# Altium schematic sheet PowerSupply.SchDoc
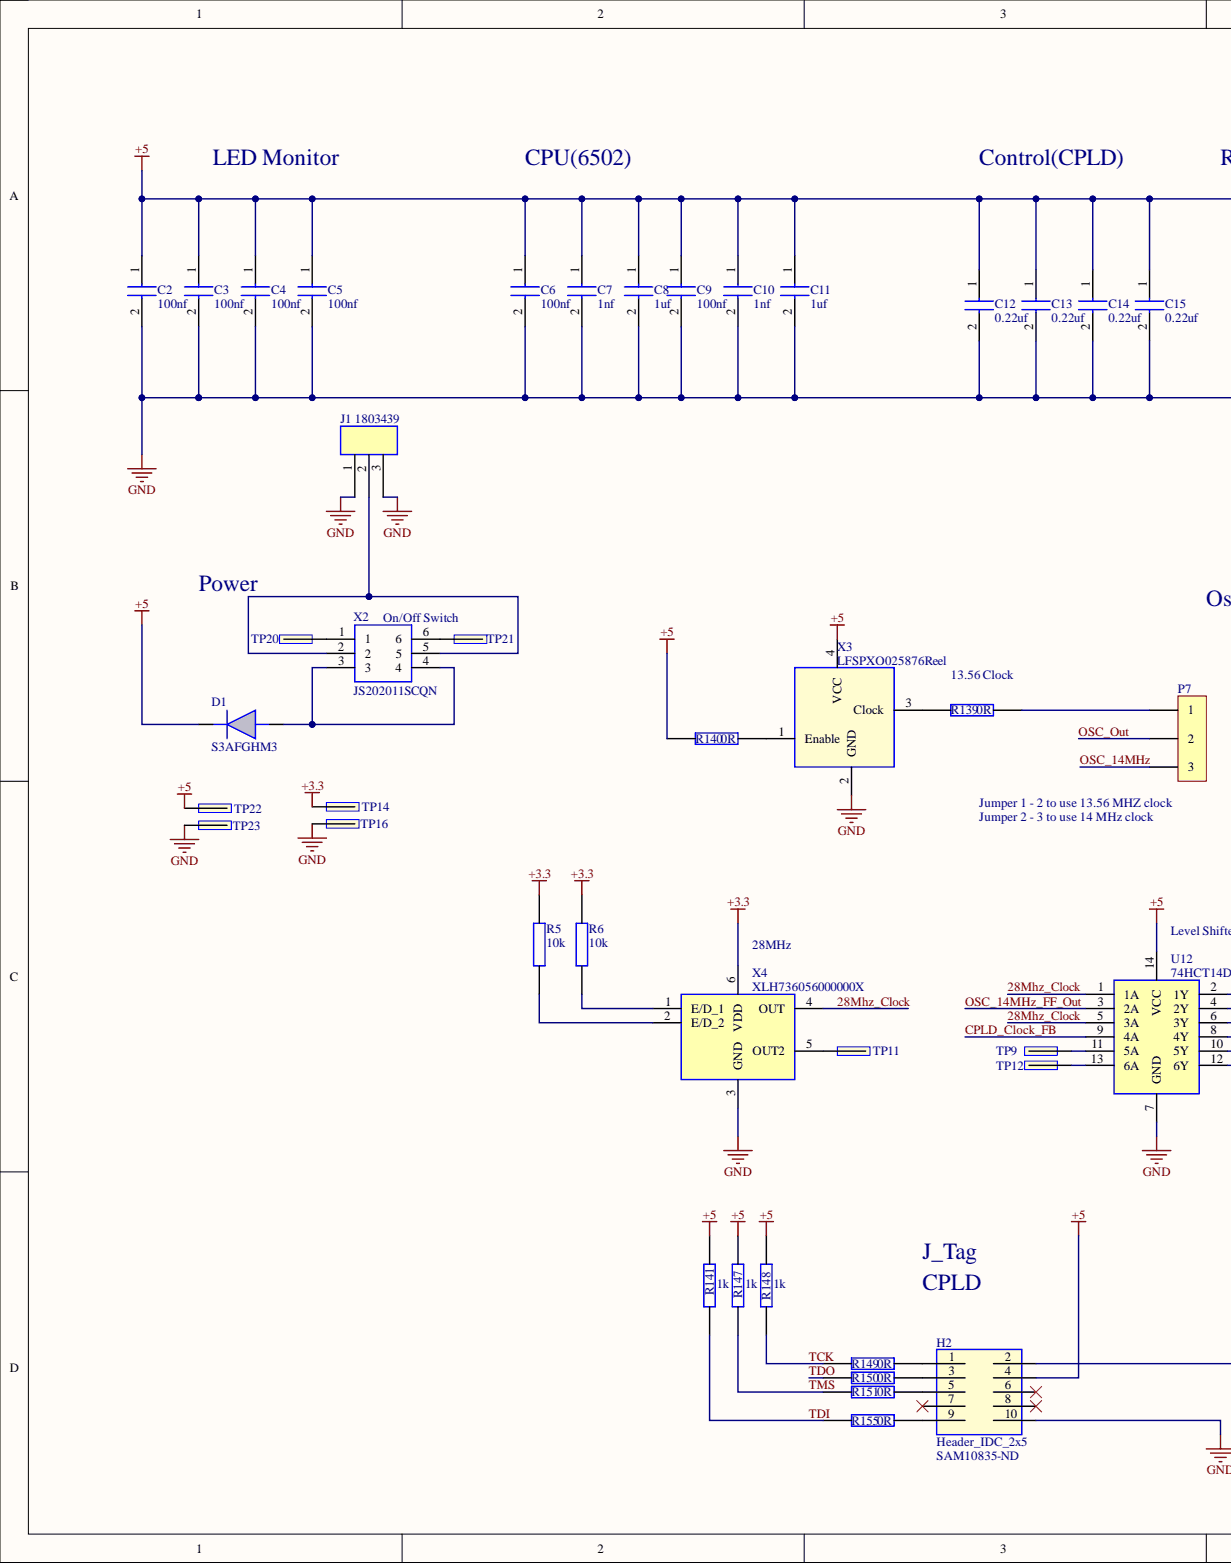
[diagram p0: PowerSupply.SchDoc, left]
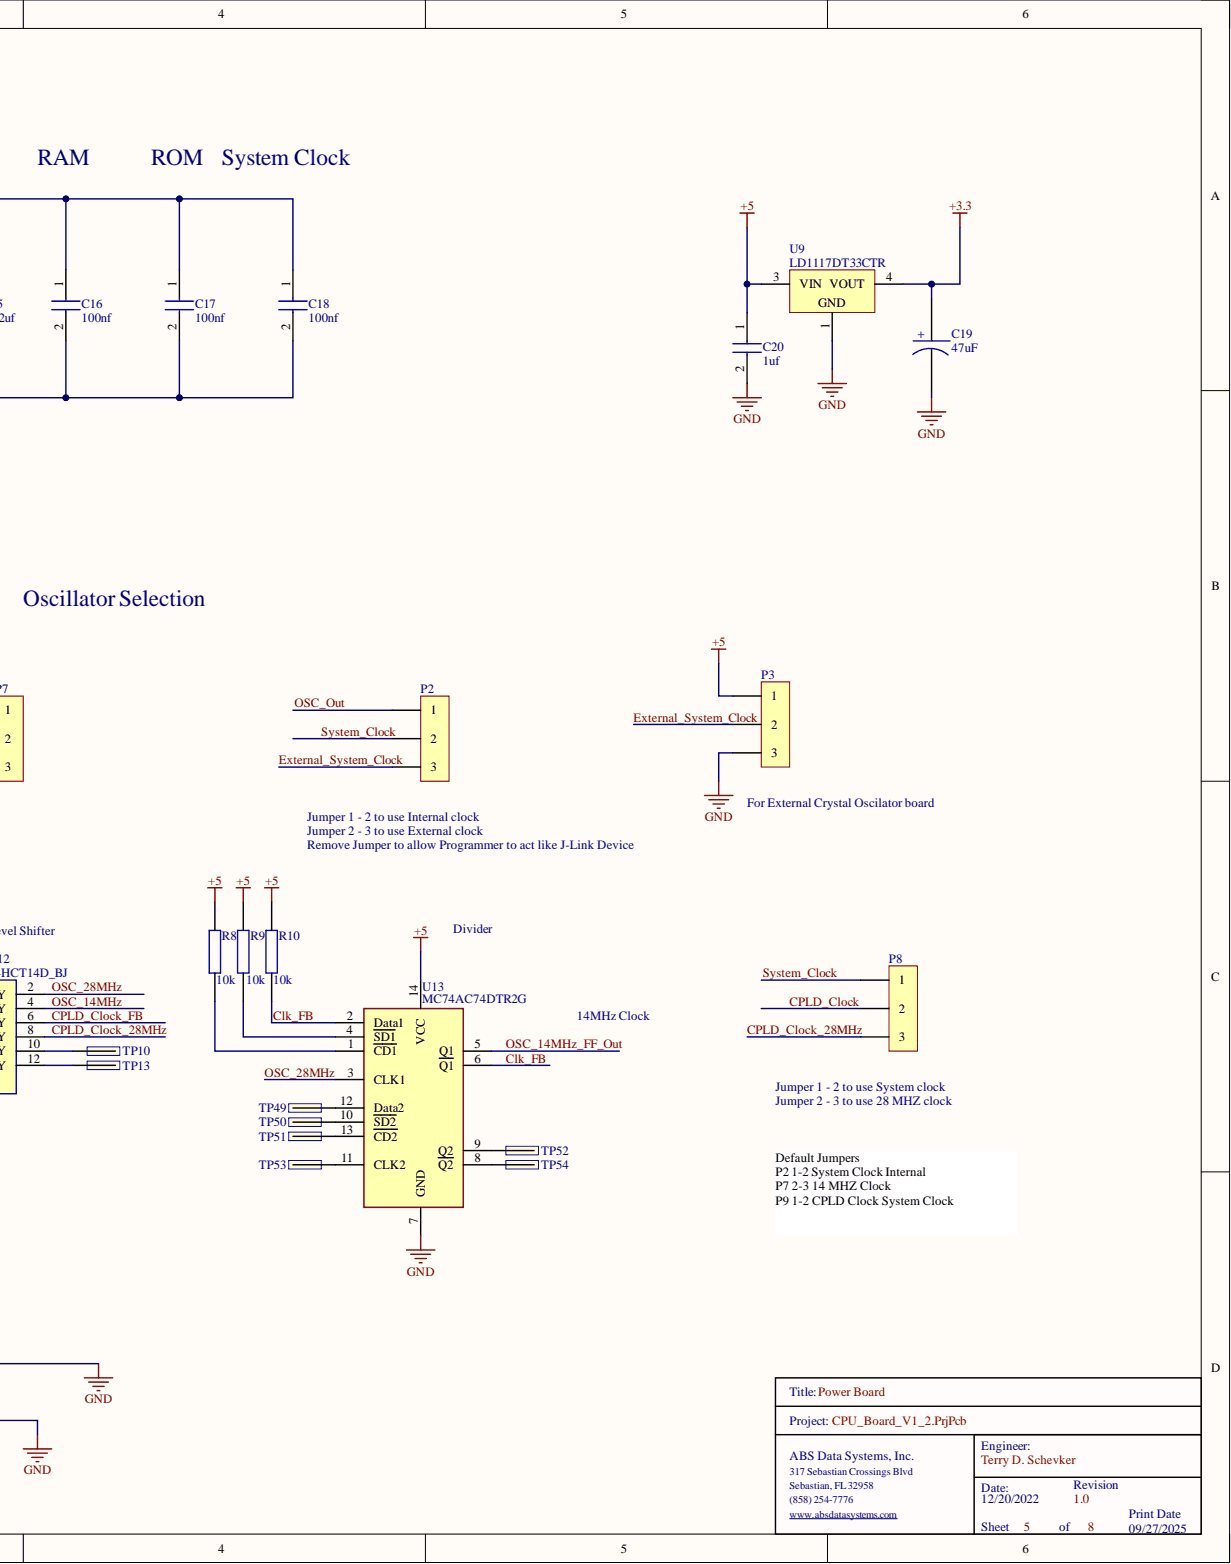
[diagram p1: PowerSupply.SchDoc, right]

## Parts
C2  100nf  [0603]
C3  100nf  [0603]
C4  100nf  [0603]
C5  100nf  [0603]
C6  100nf  [0603]
C7  1nf  [0603]
C8  1uf  [0603]
C9  100nf  [0603]
C10  1nf  [0603]
C11  1uf  [0603]
C12  0.22uf  [0603]
C13  0.22uf  [0603]
C14  0.22uf  [0603]
C15  0.22uf  [0603]
C16  100nf  [0603]
C17  100nf  [0603]
C18  100nf  [0603]
C19  47uF  [CapSMD_6.3x5.8]
C20  1uf  [0603]
D1  S3AFGHM3  [do-221ac]
H2  Header_IDC_2x5  Supplier Part Number 1: SAM10835-ND  [HDR2X5]
J1  1803439  [1803439]
P2  MHDR1X3_100  [MHDR1X3_100]  (value not drawn)
P3  MHDR1X3_100  [MHDR1X3_100]  (value not drawn)
P7  MHDR1X3_100  [MHDR1X3_100]  (value not drawn)
P8  MHDR1X3_100  [MHDR1X3_100]  (value not drawn)
R5  10k  [0603]
R6  10k  [0603]
R8  10k  [0603]
R9  10k  [0603]
R10  10k  [0603]
R139  0R  [0603]  (value not drawn)
R140  0R  [0603]  (value not drawn)
R141  1k  [0603]
R147  1k  [0603]
R148  1k  [0603]
R149  0R  [0603]  (value not drawn)
R150  0R  [0603]  (value not drawn)
R151  0R  [0603]  (value not drawn)
R155  0R  [0603]  (value not drawn)
TP9  (no value)  [TP]
TP10  TP  [TP]
TP11  TP  [TP]
TP12  (no value)  [TP]
TP13  TP  [TP]
TP14  TP  [TP]
TP16  TP  [TP]
TP20  (no value)  [TP]
TP21  (no value)  [TP]
TP22  TP  [TP]
TP23  TP  [TP]
TP49  TP  [TP]
TP50  TP  [TP]
TP51  TP  [TP]
TP52  TP  [TP]
TP53  TP  [TP]
TP54  TP  [TP]
U9  LD1117DT33CTR  [TO-252_N]
U12  74HCT14D_BJ  [SOIC127P600X175-14N]
U13  MC74AC74DTR2G  [SOP65P640X120-14N]
X2  JS202011SCQN  [JS202011SCQN]
X3  LFSPXO025876Reel  [LFSPXO025876Reel]
X4  XLH736056000000X  [XLH736056000000X]

## Nets
+3.3: C19.1 R5.2 R6.2 TP14.1 U9.4 X4.6(VDD)
+5: C2.1 C3.1 C4.1 C5.1 C6.1 C7.1 C8.1 C9.1 C10.1 C11.1 C12.1 C13.1 C14.1 C15.1 C16.1 C17.1 C18.1 C20.1 D1.1 H2.4 P3.1 R8.2 R9.2 R10.2 R140.1 R141.1 R147.1 R148.1 TP22.1 U9.3 U12.14(VCC) U13.14(VCC) X3.4(VCC)
GND: C2.2 C3.2 C4.2 C5.2 C6.2 C7.2 C8.2 C9.2 C10.2 C11.2 C12.2 C13.2 C14.2 C15.2 C16.2 C17.2 C18.2 C19.2 C20.2 H2.2 H2.10 J1.1 J1.3 P3.3 TP16.1 TP23.1 U9.1 U12.7(GND) U13.7(GND) X3.2(GND) X4.3(GND)
28Mhz_Clock: U12.1(1A) U12.5(3A) X4.4(OUT)
Clk_FB: R10.1 U13.2(Data1) U13.6(Q1)
CPLD_Clock: P8.2
CPLD_Clock_28MHz: P8.3 U12.8(4Y)
CPLD_Clock_FB: U12.6(3Y) U12.9(4A)
External_System_Clock: P2.3 P3.2
OSC_14MHz: P7.3 U12.4(2Y)
OSC_14MHz_FF_Out: U12.3(2A) U13.5(Q1)
OSC_28MHz: U12.2(1Y) U13.3(CLK1)
OSC_Out: P2.1 P7.2
System_Clock: P2.2 P8.1
TCK: R148.2 R149.1
TDI: R141.2 R155.1
TDO: R150.1
TMS: R147.2 R151.1
NetD1_2: D1.2 X2.3 X2.4
NetH2_1: H2.1 R149.2
NetH2_3: H2.3 R150.2
NetH2_5: H2.5 R151.2
NetH2_9: H2.9 R155.2
NetJ1_2: J1.2 X2.2 X2.5
NetP7_1: P7.1 R139.2
NetR5_1: R5.1 X4.2(E/D_2)
NetR6_1: R6.1 X4.1(E/D_1)
NetR8_1: R8.1 U13.1(CD1)
NetR9_1: R9.1 U13.4(SD1)
NetR139_1: R139.1 X3.3(Clock)
NetR140_2: R140.2 X3.1(Enable)
NetTP9_1: TP9.1 U12.11(5A)
NetTP10_1: TP10.1 U12.10(5Y)
NetTP11_1: TP11.1 X4.5(OUT2)
NetTP12_1: TP12.1 U12.13(6A)
NetTP13_1: TP13.1 U12.12(6Y)
NetTP20_1: TP20.1 X2.1
NetTP21_1: TP21.1 X2.6
NetTP49_1: TP49.1 U13.12(Data2)
NetTP50_1: TP50.1 U13.10(SD2)
NetTP51_1: TP51.1 U13.13(CD2)
NetTP52_1: TP52.1 U13.9(Q2)
NetTP53_1: TP53.1 U13.11(CLK2)
NetTP54_1: TP54.1 U13.8(Q2)

Not connected: H2 6 7 8

## Library data of the parts
C2-C6 C9 C12-C18 = CL10B104JB8NNNC: Samsung Electro-Mechanics 0603 100nf; Component Kind: Standard; Library Name: Capacitors.SchLib
C7 C10 = CL10B102KB8NNNL: Samsung Electro-Mechanics 0603 1nf; Component Kind: Standard; Library Name: Capacitors.SchLib
C8 C11 C20 = CL10B105KA8NNNL: Samsung Electro-Mechanics 0603 1uf; Component Kind: Standard; Library Name: Capacitors.SchLib
C19 = UUD1C470MCL1GS: CAP ALUM 47UF 20% 16V SMD; Manufacturer: Nichicon; Dielectric Material: Aluminium; Dissipation Factor: 0.2%; Impedance: 440mR; Lead Diameter: 0.8mm; Leakage Current: 3uA; Life (Hours): 2000Hour; Ripple Current: 230mA; Capacitance: 47uF; Case/Package: Radial; Category: Aluminum Electrolytic Capacitors; Component Kind: Standard; ComponentLink1Description: https://datasheet.ciiva.com/30522/getdatasheetpartid-449848-30522051.pdf?src-supplier=Verical; ComponentLink1URL: https://datasheet.ciiva.com/30522/getdatasheetpartid-449848-30522051.pdf?src-supplier=Verical; ComponentLink2Description: https://datasheet.ciiva.com/96/e-ud-96997.pdf?src-supplier=Digi-Key; ComponentLink2URL: https://datasheet.ciiva.com/96/e-ud-96997.pdf?src-supplier=Digi-Key; ComponentLink3Description: https://datasheet.ciiva.com/11776/0900766b80fa39e7-11776889.pdf?src-supplier=RSComponents; ComponentLink3URL: https://datasheet.ciiva.com/11776/0900766b80fa39e7-11776889.pdf?src-supplier=RSComponents; ComponentLink4Description: https://datasheet.ciiva.com/96/e-ud-96998.pdf?src-supplier=Digi-Key; ComponentLink4URL: https://datasheet.ciiva.com/96/e-ud-96998.pdf?src-supplier=Digi-Key; ComponentLink5Description: https://datasheet.ciiva.com/96/e-ud-96999.pdf?src-supplier=Digi-Key; ComponentLink5URL: https://datasheet.ciiva.com/96/e-ud-96999.pdf?src-supplier=Digi-Key; ComponentLink6Description: https://datasheet.ciiva.com/11686/e-ud-11686236.pdf?src-supplier=Avnet; ComponentLink6URL: https://datasheet.ciiva.com/11686/e-ud-11686236.pdf?src-supplier=Avnet; ComponentLink7Description: https://datasheet.ciiva.com/16502/e-ud-16502750.pdf?src-supplier=Future+Electronics; ComponentLink7URL: https://datasheet.ciiva.com/16502/e-ud-16502750.pdf?src-supplier=Future+Electronics; ComponentLink8Description: https://datasheet.ciiva.com/7781/e-ud-7781695.pdf?src-supplier=Element14; ComponentLink8URL: https://datasheet.ciiva.com/7781/e-ud-7781695.pdf?src-supplier=Element14; Contact Plating: Tin; Diameter: 6.3mm; Height: 5.8mm; Height - Seated (Max): 0.228inch; Lead Free: Lead Free; Lead Pitch: 2.2mm; Length: 5.8mm; Library Name: Capacitors.SchLib; Manufacturer Part Number: UUD1C470MCL1GS; Max Operating Temperature: 105°C; Min Operating Temperature: -55°C; Number of Pins: 2; Package Quantity: 1000; Packaging: Tape and Reel; Pricing 1: 1=0.50000, 10=0.34600, 50=0.24220, 100=0.20760, 500=0.15568 (USD); Radiation Hardening: No; REACH SVHC: No SVHC; Ripple Current (AC): 230mA; RoHS: Yes; Stock 1: 26327; Supplier 1: Digi-Key; Supplier Part Number 1: 493-2259-1-ND; Termination: SMD/SMT; Tolerance: 20%; Voltage: 16V; Voltage Rating: 16V; Voltage Rating (DC): 16V
D1 = S3AFGHM3/I: Rectifiers VR=400V, IO=3A; Manufacturer_Name: Comchip Technology; Manufacturer_Part_Number: 1N4001-G; Component Kind: Standard; Datasheet Link: https://datasheet.datasheetarchive.com/originals/distributors/Datasheets-7/DSA-138621.pdf; Mouser Part Number: 750-1N4001-G; Mouser Price/Stock: https://www.mouser.co.uk/ProductDetail/Comchip-Technology/1N4001-G?qs=2qJf6qQ4IOKJYZQUWvVExw%3D%3D; Library Name: SemiConductors.SchLib
H2 = Header_IDC_2x5: 10 Pin 2x5 Through Hold IDC Connector; Manufacturer: Samtec; Manufacturer Part Number: TST-105-01-G-D; Connector Type: Male Pin; Gender: Male; Number of Contacts: 16; Pitch: 2.54mm; Row Spacing: 0.1inch; Category: Headers and Wire Housings; Color: Black; Component Kind: Standard; ComponentLink1Description: https://datasheet.ciiva.com/739/tst-mkt-739845.pdf?src-supplier=Digi-Key; ComponentLink1URL: https://datasheet.ciiva.com/739/tst-mkt-739845.pdf?src-supplier=Digi-Key; ComponentLink2Description: https://datasheet.ciiva.com/10018/htst-10018510.pdf?src-supplier=Avnet; ComponentLink2URL: https://datasheet.ciiva.com/10018/htst-10018510.pdf?src-supplier=Avnet; Contact Material: Bronze; Contact Mating Length: 0.25inch; Contact Plating: Gold; Depth: 9.27mm; Housing Color: Black; Housing Material: Polyester; Length: 27.94mm; Library Name: Misc.SchLib; Max Operating Temperature: 125°C; Min Operating Temperature: -55°C; Mount: Through Hole; Number of Positions: 16; Number of Rows: 2; Orientation: Straight; Packaging: Rail/Tube; Pricing 1: 1=2.85000, 10=2.73600, 100=2.28000, 500=1.93800, 1000=1.65300, 5000=1.48200 (USD); REACH SVHC: No SVHC; RoHS: Yes; Stock 1: 227; Supplier 1: Digi-Key; Termination: Solder
J1 = 1803439: Connector; Manufacturer_Name: Phoenix Contact; Arrow Part Number: 1803439; Arrow Price/Stock: https://www.arrow.com/en/products/1803439/phoenix-contact?region=nac; Component Kind: Standard; Datasheet Link: http://uk.rs-online.com/web/p/products/2204838; Library Name: Connectors.SchLib; Manufacturer_Part_Number: 1803439; Mouser Part Number: 651-1803439; Mouser Price/Stock: https://www.mouser.co.uk/ProductDetail/Phoenix-Contact/1803439?qs=ChwaSN6Xm4%2FKqu7eZUAe4w%3D%3D
P2 P3 P7 P8 = MHDR1X3_100: Header, 3-Pin; Component Kind: Standard; LatestRevisionDate: 17-Jul-2002; LatestRevisionNote: Re-released for DXP Platform.; Library Name: Connectors.SchLib; Publisher: Altium Limited
R5 R6 R8-R10 = RC0603JR-0710KL: YAGEO 0603 10k ohm; Manufacturer_Name: KEMET; Manufacturer_Part_Number: RC0603JR-070RL; Geometry.Height: 0.55mm; Component Kind: Standard; Datasheet Link: https://datasheet.datasheetarchive.com/originals/distributors/Datasheets_SAMA/902f9e387b938f871d31120f5fc1d65e.pdf; Library Name: Resistors.SchLib; Mouser Part Number: 603-RC0603JR-070RL; Mouser Price/Stock: https://www.mouser.co.uk/ProductDetail/YAGEO/RC0603JR-070RL?qs=2cAdsCoAWRGWyPcuXgGiXQ%3D%3D
R139 R140 R149-R151 R155 = RC0603JR-070RL: YAGEO 0603 0 ohm; Manufacturer_Name: KEMET; Geometry.Height: 0.55mm; Component Kind: Standard; Datasheet Link: https://datasheet.datasheetarchive.com/originals/distributors/Datasheets_SAMA/902f9e387b938f871d31120f5fc1d65e.pdf; Library Name: Resistors.SchLib; Manufacturer_Part_Number: RC0603JR-070RL; Mouser Part Number: 603-RC0603JR-070RL; Mouser Price/Stock: https://www.mouser.co.uk/ProductDetail/YAGEO/RC0603JR-070RL?qs=2cAdsCoAWRGWyPcuXgGiXQ%3D%3D
R141 R147 R148 = AC0603FR-7W1KL: YAGEO 0603 1k ohm; Manufacturer_Name: KEMET; Manufacturer_Part_Number: RC0603JR-070RL; Geometry.Height: 0.55mm; Component Kind: Standard; Datasheet Link: https://datasheet.datasheetarchive.com/originals/distributors/Datasheets_SAMA/902f9e387b938f871d31120f5fc1d65e.pdf; Library Name: Resistors.SchLib; Mouser Part Number: 603-RC0603JR-070RL; Mouser Price/Stock: https://www.mouser.co.uk/ProductDetail/YAGEO/RC0603JR-070RL?qs=2cAdsCoAWRGWyPcuXgGiXQ%3D%3D
TP9-TP14 TP16 TP20-TP23 TP49-TP54 = TP: Manufacturer: Samtec; Manufacturer Part Number: TST-105-01-G-D; Connector Type: Male Pin; Gender: Male; Number of Contacts: 16; Pitch: 2.54mm; Row Spacing: 0.1inch; Category: Headers and Wire Housings; Color: Black; Component Kind: Standard; ComponentLink1Description: https://datasheet.ciiva.com/739/tst-mkt-739845.pdf?src-supplier=Digi-Key; ComponentLink1URL: https://datasheet.ciiva.com/739/tst-mkt-739845.pdf?src-supplier=Digi-Key; ComponentLink2Description: https://datasheet.ciiva.com/10018/htst-10018510.pdf?src-supplier=Avnet; ComponentLink2URL: https://datasheet.ciiva.com/10018/htst-10018510.pdf?src-supplier=Avnet; Contact Material: Bronze; Contact Mating Length: 0.25inch; Contact Plating: Gold; Depth: 9.27mm; Housing Color: Black; Housing Material: Polyester; Length: 27.94mm; Library Name: Misc.SchLib; Max Operating Temperature: 125°C; Min Operating Temperature: -55°C; Mount: Through Hole; Number of Positions: 16; Number of Rows: 2; Orientation: Straight; Packaging: Rail/Tube; Pricing 1: 1=2.85000, 10=2.73600, 100=2.28000, 500=1.93800, 1000=1.65300, 5000=1.48200 (USD); REACH SVHC: No SVHC; RoHS: Yes; Stock 1: 227; Supplier 1: Digi-Key; Termination: Solder
U9 = PM-REG-LD1117-SOT3: 3.3V Voltage Regulator SMD; Component Kind: Standard; ComponentLink1Description: Manufacturer URL; ComponentLink1URL: http://www.st.com; ComponentLink2Description: Datasheet; ComponentLink2URL: http://www.st.com/internet/com/TECHNICAL_RESOURCES/TECHNICAL_LITERATURE/DATASHEET/CD00000544.pdf; DatasheetVersion: 1/11/2011; Library Name: Misc.SchLib; PackageDescription: 3-Pin Transistor Outline Package 2.28 mm Pitch, 9.7 mm Lead Span (DPAK); PackageReference: TO-252; PackageVersion: 2011
U12 = 74HCT14D_BJ: Inveter; Component Kind: Standard; Library Name: Logic.SchLib
U13 = MC74AC74DTR2G: FlipFlop; Component Kind: Standard; Library Name: Logic.SchLib
X2 = JS202011SCQN: Sub miniature slide switches; Manufacturer_Name: C & K COMPONENTS; Geometry.Height: 5.5mm; Component Kind: Standard; Datasheet Link: http://www.ckswitches.com/media/1422/js.pdf; Library Name: Misc.SchLib; Manufacturer_Part_Number: JS202011SCQN; Mouser Part Number: 611-JS202011SCQN; Mouser Price/Stock: https://www.mouser.co.uk/ProductDetail/CK/JS202011SCQN?qs=LgMIjt8LuD95JYWxZ7NvZA%3D%3D
X3 = LFSPXO025876Reel: Standard Clock Oscillators 13.56MHz 5V 100ppm -40C +85C; Manufacturer_Name: IQD; Geometry.Height: 1.4mm; Component Kind: Standard; Datasheet Link: https://componentsearchengine.com/Datasheets/1/LFSPXO025876Reel.pdf; Library Name: Misc.SchLib; Manufacturer_Part_Number: LFSPXO025876Reel; Mouser Part Number: 449-LFSPXO025876REEL; Mouser Price/Stock: https://www.mouser.co.uk/ProductDetail/IQD/LFSPXO025876Reel?qs=e4%2FAndAAwgKQk%252Bo7MiilFg%3D%3D
X4 = XLH736056000000X: Crystall Oscillator; Component Kind: Standard; Library Name: Misc.SchLib
